# Altium schematic sheet Input Protection.SchDoc
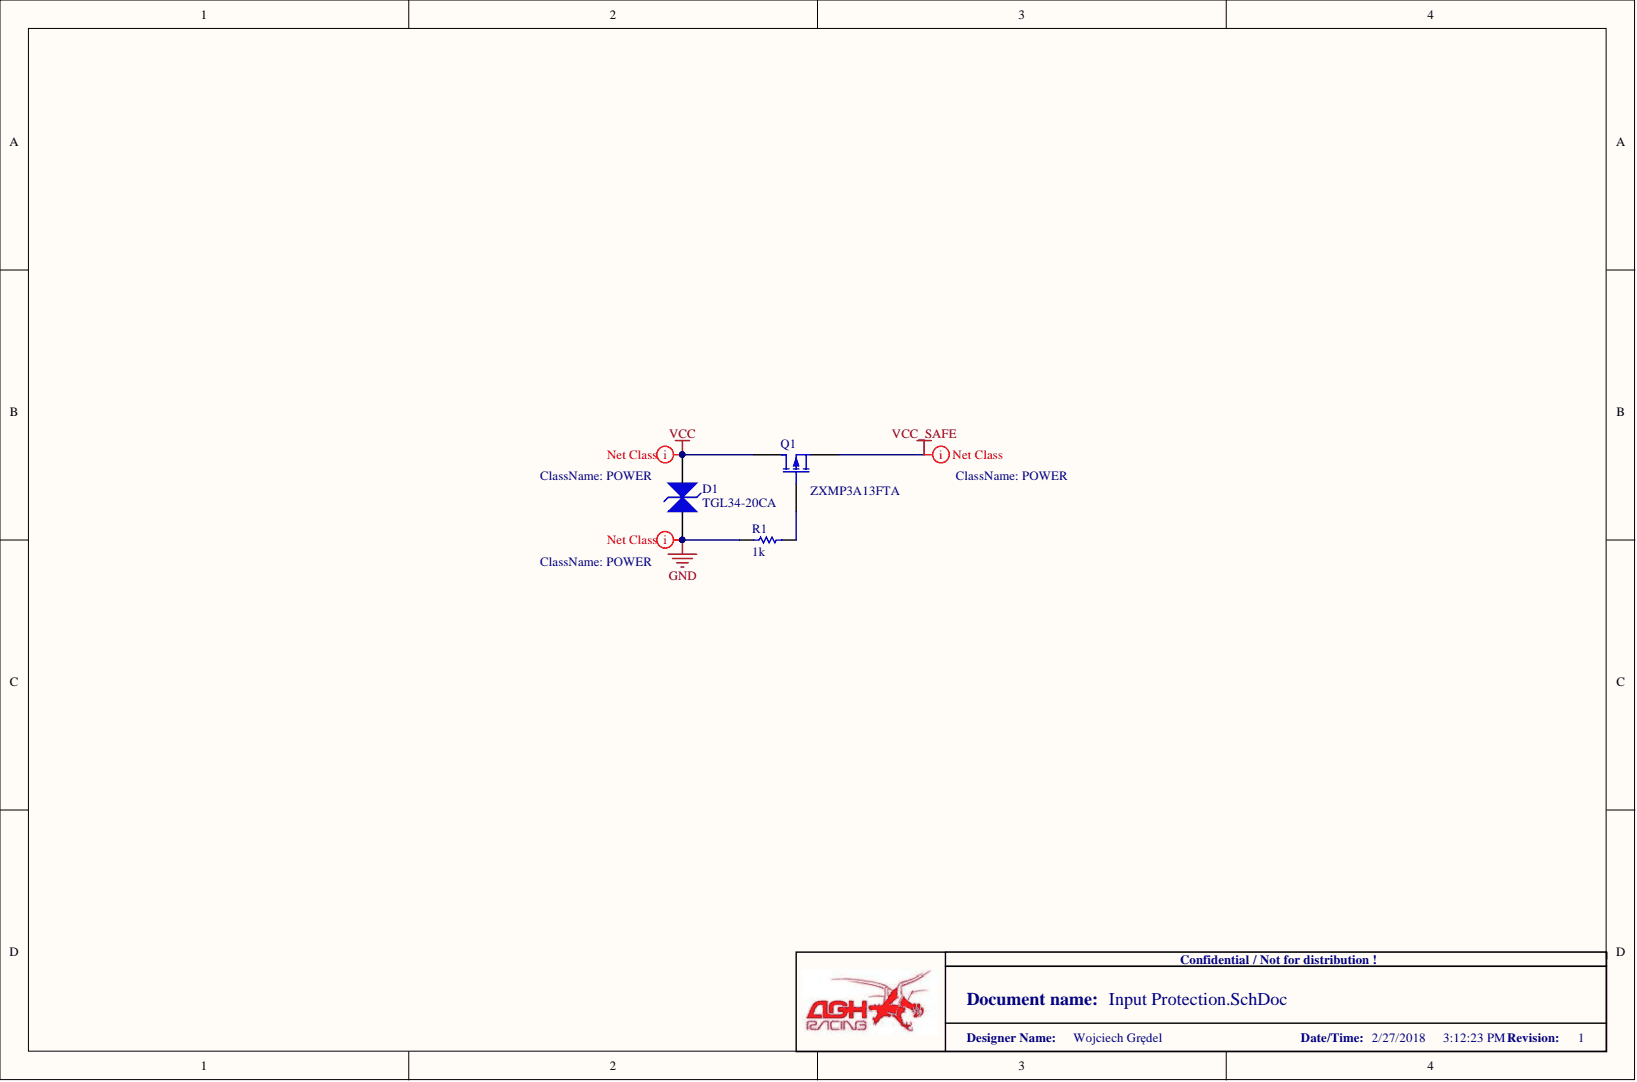
[diagram p0: Input Protection.SchDoc]

## Parts
D1  TGL34-20CA  [DIO_MELF_IPC_MD]
Q1  ZXMP3A13FTA  [SOT23_IPC_MD]
R1  value 1k  [RES_0603_IPC_HD]  (hidden comment: Res)

## Nets
GND: D1.1 R1.2
VCC: D1.2 Q1.3
VCC_SAFE: Q1.2
NetQ1_1: Q1.1 R1.1

## Library data of the parts
D1 = TGL34-20CA: Transil; Component Kind: Standard; Library Name: agh_racing_2018.SchLib
Q1 = ZXMP3A13FTA: P-mosfet; Component Kind: Standard; Library Name: agh_racing_2018.SchLib
R1 = Res: Resistor; Component Kind: Standard; Library Name: agh_racing_2018.SchLib
